# Altium schematic sheet SC130028Q.SchDoc
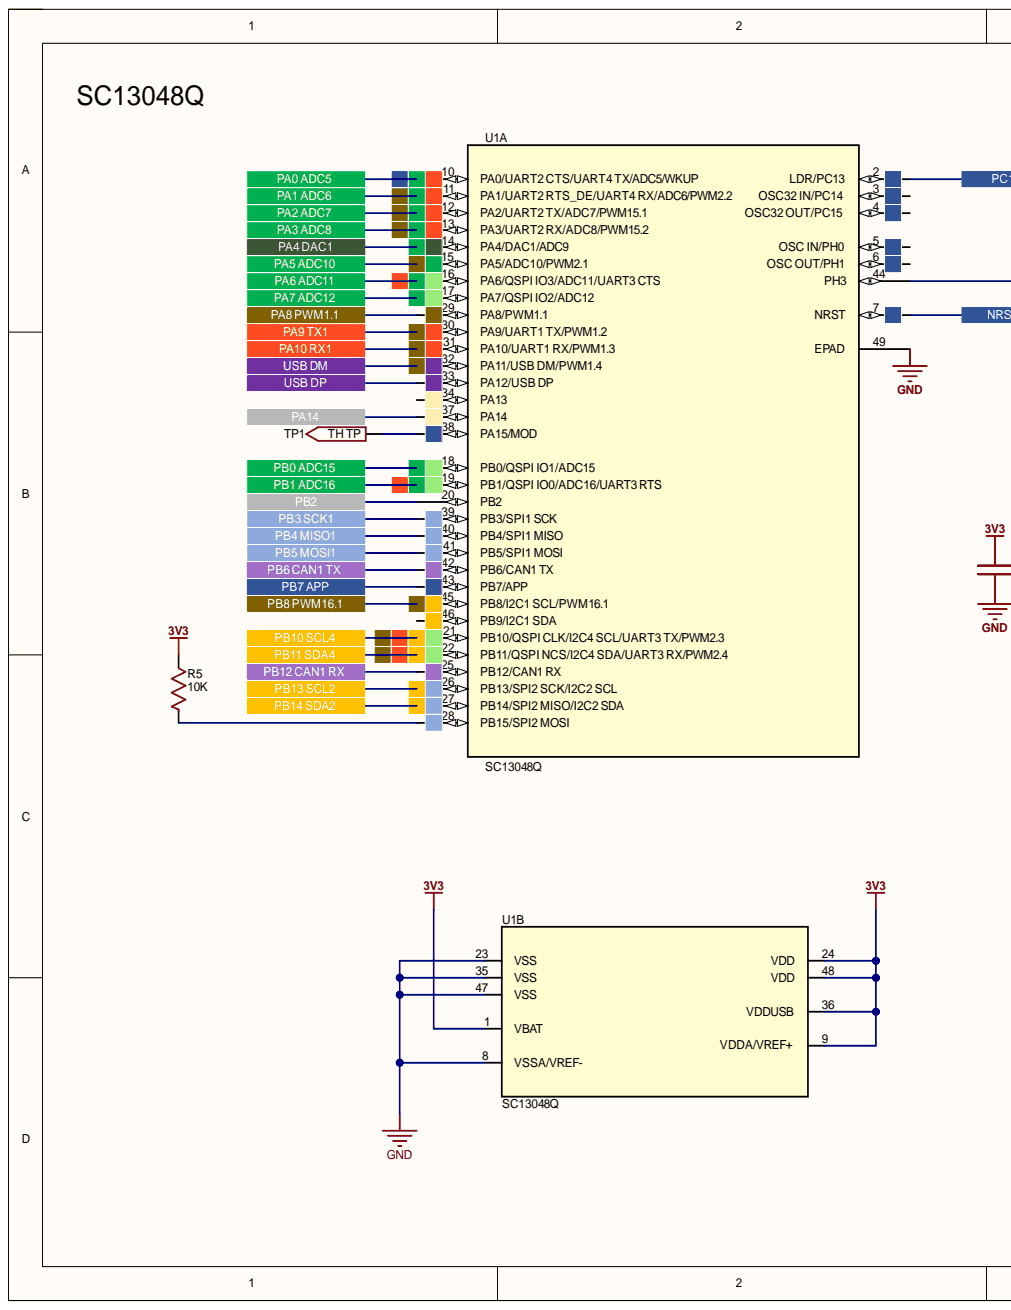
[diagram p0: SC130028Q.SchDoc, left]
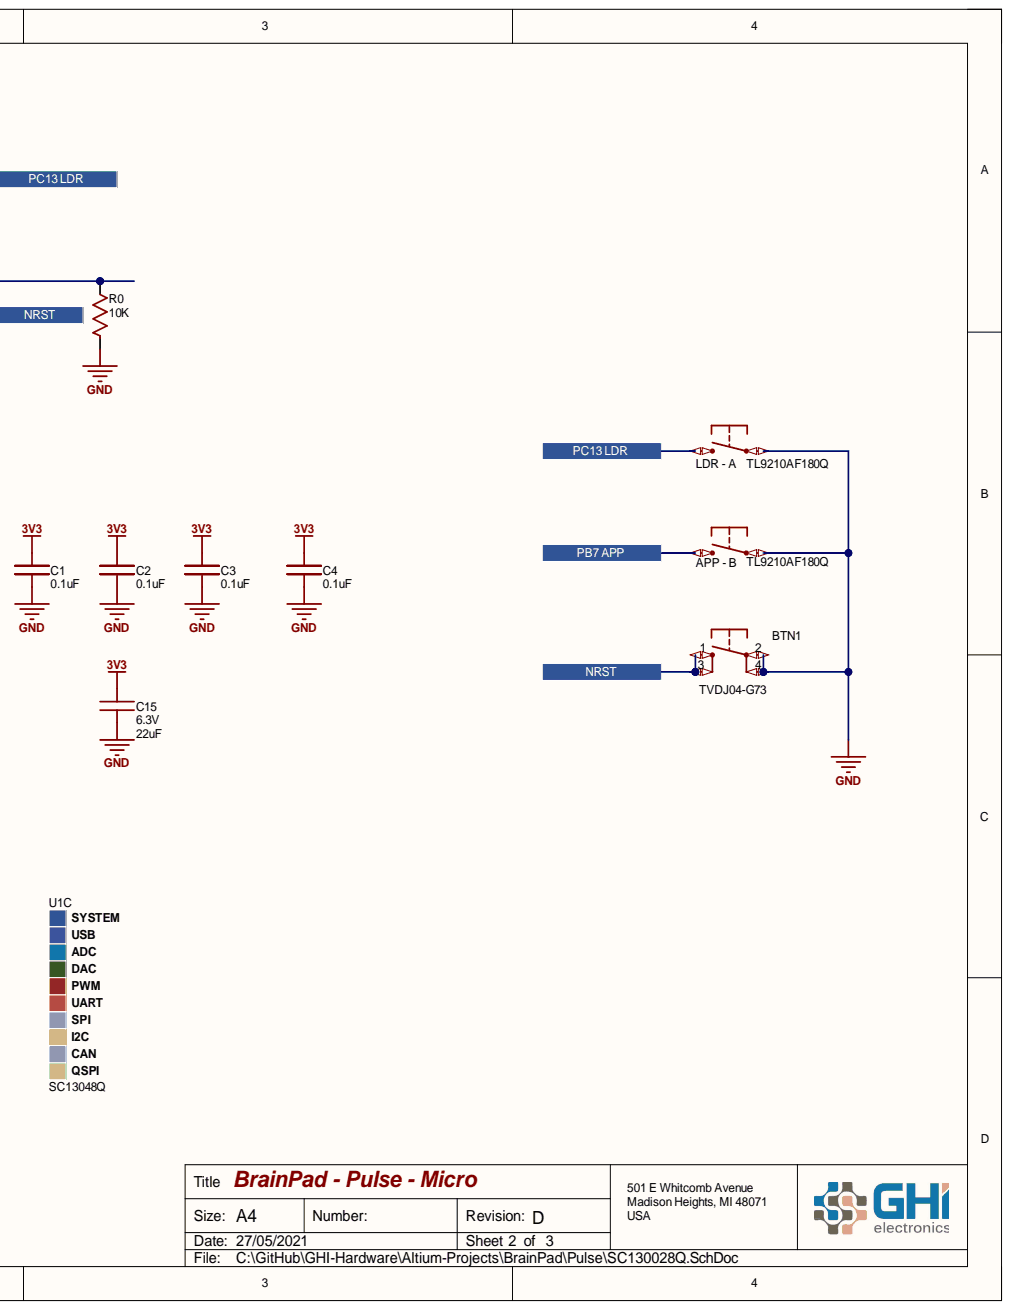
[diagram p1: SC130028Q.SchDoc, right]

## Parts
APP_-_B  TL9210AF180Q  [Button - TL9210]
BTN1  TVDJ04-G73  [6MM_TACTILE-SWITCH_J-BEND]
C1  value 0.1uF  Capacitance: 0.1uF  [C0402]  (hidden comment: 0402 Cap 0.1uF)
C2  value 0.1uF  Capacitance: 0.1uF  [C0402]  (hidden comment: 0402 Cap 0.1uF)
C3  value 0.1uF  Capacitance: 0.1uF  [C0402]  (hidden comment: 0402 Cap 0.1uF)
C4  value 0.1uF  Capacitance: 0.1uF  [C0402]  (hidden comment: 0402 Cap 0.1uF)
C15  value 22uF  Capacitance: 22uF  Voltage: 6.3V  [C0805 L]  (hidden comment: 0805 Cap 22uF)
LDR_-_A  TL9210AF180Q  [Button - TL9210]
R0  value 10K  Resistance: 10K  [R0402]  (hidden comment: 0402 Res 10K)
R5  value 10K  Resistance: 10K  [R0402]  (hidden comment: 0402 Res 10K)
TP1  TH Testpad 32mil (32mil ar)  [32mil TH]  (value not drawn)  (no BOM)
U1  SC13048Q  [UFQFPN48-7x7mm_STM]  (parts A,B)
U1C  SC13048Q  [UFQFPN48-7x7mm_STM]

## Ports
NRST, PA0 ADC5, PA1 ADC6, PA2 ADC7, PA3 ADC8, PA4 DAC1, PA5 ADC10, PA6 ADC11, PA7 ADC12, PA8 PWM1.1, PA9 TX1, PA10 RX1, PA14, PB0 ADC15, PB1 ADC16, PB2, PB3 SCK1, PB4 MISO1, PB5 MOSI1, PB6 CAN1 TX, PB7 APP, PB8 PWM16.1, PB10 SCL4, PB11 SDA4, PB12 CAN1 RX, PB13 SCL2, PB14 SDA2, PC13 LDR, USB DM, USB DP

## Nets
3V3: C1.2 C2.2 C3.2 C4.2 C15.2 R5.2 U1.1(VBAT) U1.9(VDDA/VREF+) U1.24(VDD) U1.36(VDDUSB) U1.48(VDD)
GND: APP_-_B.2 BTN1.2 BTN1.4 C1.1 C2.1 C3.1 C4.1 C15.1 LDR_-_A.2 R0.1 U1.8(VSSA/VREF-) U1.23(VSS) U1.35(VSS) U1.47(VSS) U1.49(EPAD)
NRST: BTN1.1 BTN1.3 U1.7(NRST) ; port NRST
PA0 ADC5: U1.10 ; port PA0 ADC5
PA1 ADC6: U1.11 ; port PA1 ADC6
PA2 ADC7: U1.12(PA2/UART2_TX/ADC7/PWM15.1) ; port PA2 ADC7
PA3 ADC8: U1.13(PA3/UART2_RX/ADC8/PWM15.2) ; port PA3 ADC8
PA4 DAC1: U1.14(PA4/DAC1/ADC9) ; port PA4 DAC1
PA5 ADC10: U1.15(PA5/ADC10/PWM2.1) ; port PA5 ADC10
PA6 ADC11: U1.16 ; port PA6 ADC11
PA7 ADC12: U1.17(PA7/QSPI_IO2/ADC12) ; port PA7 ADC12
PA8 PWM1.1: U1.29(PA8/PWM1.1) ; port PA8 PWM1.1
PA9 TX1: U1.30(PA9/UART1_TX/PWM1.2) ; port PA9 TX1
PA10 RX1: U1.31(PA10/UART1_RX/PWM1.3) ; port PA10 RX1
PA14: U1.37(PA14) ; port PA14
PB0 ADC15: U1.18(PB0/QSPI_IO1/ADC15) ; port PB0 ADC15
PB1 ADC16: U1.19(PB1/QSPI_IO0/ADC16/UART3_RTS) ; port PB1 ADC16
PB2: U1.20(PB2) ; port PB2
PB3 SCK1: U1.39(PB3/SPI1_SCK) ; port PB3 SCK1
PB4 MISO1: U1.40(PB4/SPI1_MISO) ; port PB4 MISO1
PB5 MOSI1: U1.41(PB5/SPI1_MOSI) ; port PB5 MOSI1
PB6 CAN1 TX: U1.42(PB6/CAN1_TX) ; port PB6 CAN1 TX
PB7 APP: APP_-_B.1 U1.43(PB7/APP) ; port PB7 APP
PB8 PWM16.1: U1.45(PB8/I2C1_SCL/PWM16.1) ; port PB8 PWM16.1
PB10 SCL4: U1.21(PB10/QSPI_CLK/I2C4_SCL/UART3_TX/PWM2.3) ; port PB10 SCL4
PB11 SDA4: U1.22(PB11/QSPI_NCS/I2C4_SDA/UART3_RX/PWM2.4) ; port PB11 SDA4
PB12 CAN1 RX: U1.25(PB12/CAN1_RX) ; port PB12 CAN1 RX
PB13 SCL2: U1.26(PB13/SPI2_SCK/I2C2_SCL) ; port PB13 SCL2
PB14 SDA2: U1.27(PB14/SPI2_MISO/I2C2_SDA) ; port PB14 SDA2
PC13 LDR: LDR_-_A.1 U1.2(LDR/PC13) ; port PC13 LDR
USB DM: U1.32(PA11/USB_DM/PWM1.4) ; port USB DM
USB DP: U1.33(PA12/USB_DP) ; port USB DP
NetR0_2: R0.2 U1.44(PH3)
NetR5_1: R5.1 U1.28(PB15/SPI2_MOSI)
NetTP1_1: TP1.1 U1.38(PA15/MOD)

Not connected: U1 3(OSC32_IN/PC14) 4(OSC32_OUT/PC15) 5(OSC_IN/PH0) 6(OSC_OUT/PH1) 34(PA13) 46(PB9/I2C1_SDA)

## Library data of the parts
APP_-_B LDR_-_A = TL9210AF180Q: E-Switch 6mm Tactile Switch; GateName_1: G$1; SymbolName_1: TL9210; Component Kind: Standard; DeviceSetName: TL9210AF180Q; Library Name: GHI-Mechanical.SchLib; LibraryName: GHI-InputDevices
BTN1 = 6MM TACTILE SWITCH J-BEND: Component Kind: Standard; Value: TVDJ04-G73; Library Name: GHI-Mechanical.SchLib
C1-C4 = 0402 Cap 0.1uF: 0.1uF ±10% 16V X7R 0402 Multilayer Ceramic Capacitors MLCC - SMD/SMT RoHS; Mfr.Part #: CC0402KRX7R7BB104; Category: Ceramic; Component Kind: Standard; LCSC Part #: C60474; Package: 0402; Tolerance: ±10%; Voltage: 16V; Library Name: GHI-Passives.SchLib
C15 = 0805 Cap 22uF: 22uF ±20% 6.3V X5R 0805 Multilayer Ceramic Capacitors MLCC - SMD/SMT RoHS; Mfr.Part #: CL21A226MQCLRNC; Category: Ceramic; Component Kind: Standard; LCSC Part #: C307530; Package: 0805; Tolerance: ±20%; Library Name: GHI-Passives.SchLib
R0 R5 = 0402 Res 10K: 10K ±1% 1/16W ±100ppm/℃ 0402 Chip Resistor - Surface Mount RoHS; Manufacturer: YAGEO; Mfr.Part #: RC0402FR-0710KL; Voltage Rating: *V; Component Kind: Standard; LCSC Part #: C60490; Package: 0402; Power Rating: 1/16W; Resistance Tolerance: ±1%; Library Name: GHI-Passives.SchLib
TP1 = TH Testpad 32mil (32mil ar): TH Testpad 32mil (32mil annular ring); Library Name: GHI.SchLib
U1 U1C = SC13048Q: No Description Available; Component Kind: Standard; Value: SC13048Q; Library Name: GHI-Integrated Circuits.SchLib
